# Altium schematic sheet Power.SchDoc
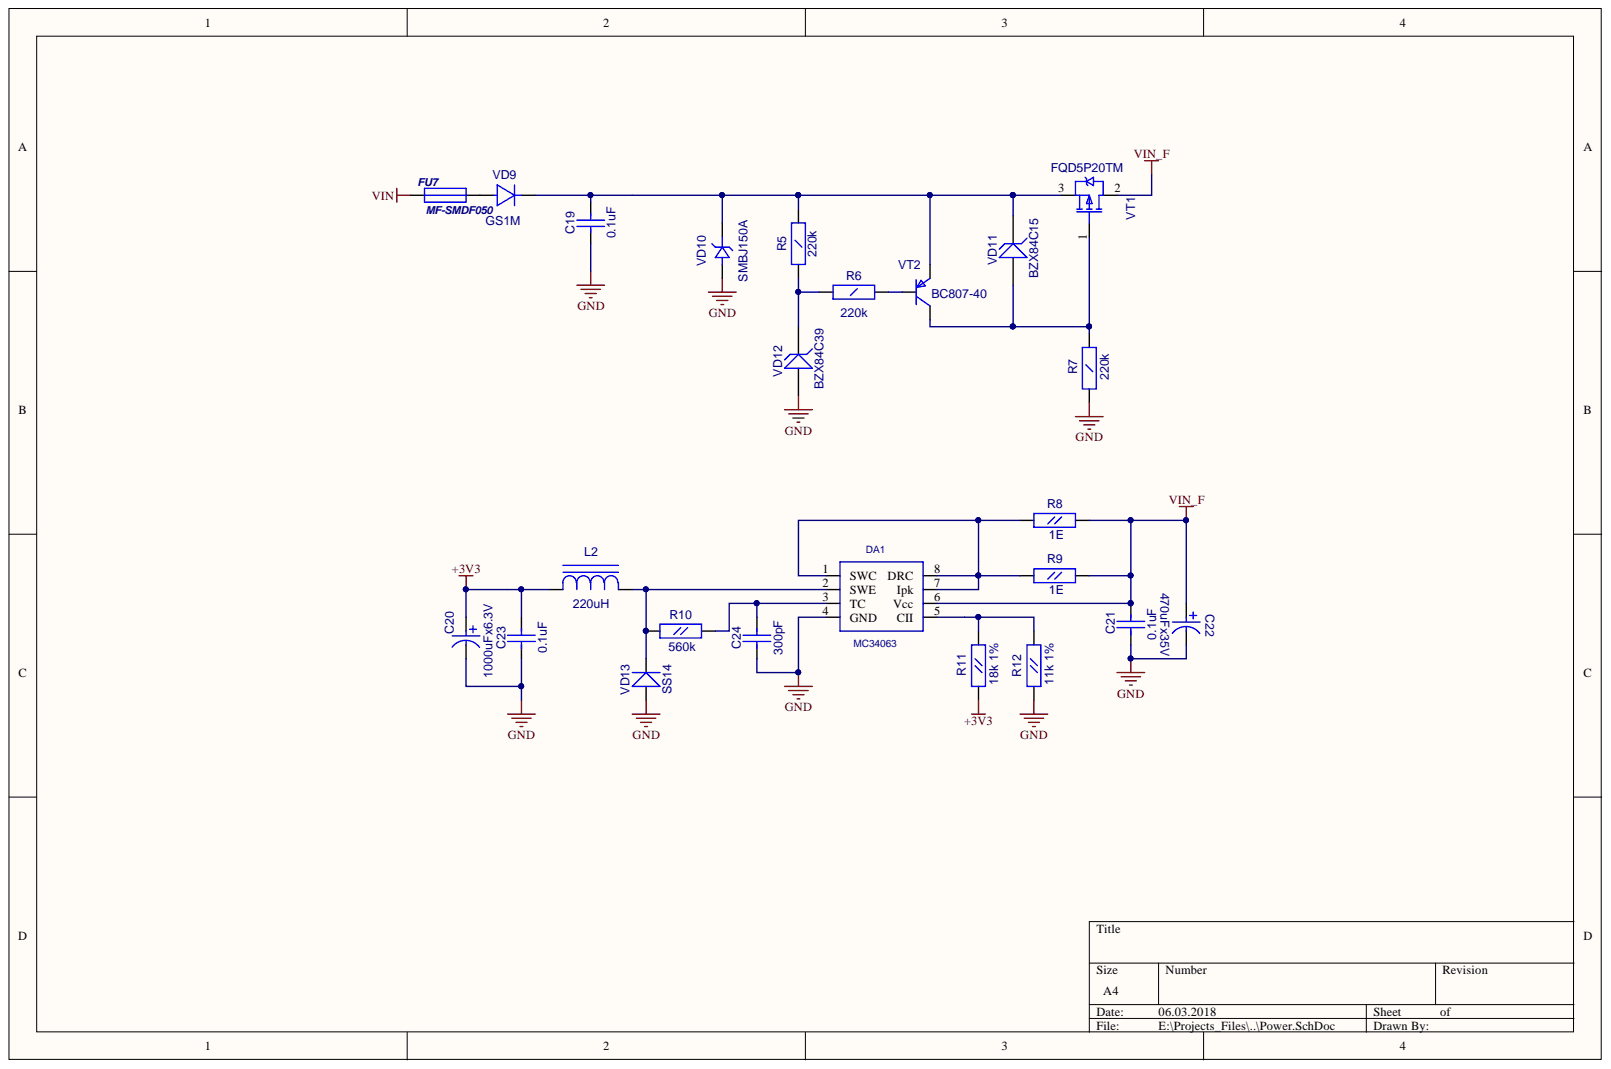
[diagram p0: Power.SchDoc]

## Parts
C19  0.1uF  [CC1206]
C20  1000uFx6.3V  [CC8X3.5]
C21  0.1uF  [CC0603]
C22  470uFx35V  [CC16X10_CAP0.5(1)(Primary)]
C23  0.1uF  [CC0603]
C24  300pF  [CC0603]
DA1  MC34063  [SO-8]
FU7  MF-SMDF050  [MF-SMDF]
L2  220uH  [SDR0805]
R5  220k  [RC1206]
R6  220k  [RC1206]
R7  220k  [RC1206]
R8  1E  [RC0805]
R9  1E  [RC0805]
R10  560k  [RC0603]
R11  18k 1%  [RC0603]
R12  11k 1%  [RC0603]
VD9  GS1M  [SMA]
VD10  SMBJ150A  [SMB]
VD11  BZX84C15  [SOT-23(123)]
VD12  BZX84C39  [SOT-23(123)]
VD13  SS14  [SMA]
VT1  FQD5P20TM  [D-PAK(TO-252AA)]
VT2  BC807-40  [SOT-23(123)]

## Nets
+3V3: C20.1 C23.2 L2.1 R11.1
GND: C19.1 C20.2 C21.1 C22.1 C23.1 C24.1 DA1.4(GND) R7.2 R12.1 VD10.1 VD12.1 VD13.1
VIN: FU7.1
VIN_F: C21.2 C22.2 DA1.6(Vcc) R8.2 R9.2 VT1.2
NetC19_2: C19.2 R5.2 VD9.2 VD10.2 VD11.3 VT1.3 VT2.2
NetC24_2: C24.2 DA1.3(TC) R10.2
NetDA1_1: DA1.1(SWC) DA1.7(Ipk) DA1.8(DRC) R8.1 R9.1
NetDA1_2: DA1.2(SWE) L2.2 R10.1 VD13.2
NetDA1_5: DA1.5(CII) R11.2 R12.2
NetFU7_2: FU7.2 VD9.1
NetR5_1: R5.1 R6.1 VD12.3
NetR6_2: R6.2 VT2.1
NetR7_1: R7.1 VD11.1 VT1.1 VT2.3

## Library data of the parts
C19 = CC1206: Component Kind: Standard; Description: CC1206; Value: 0.1uF; Library Name: gprs_modem_2.SchLib
C20 = CAP0.35: Component Kind: Standard; Description: CAP0.35; Value: 1000uFx6.3V; Library Name: gprs_modem_2.SchLib
C21 C23 C24 = CC0603: Component Kind: Standard; Description: CC0603; Library Name: gprs_modem_2.SchLib
  C21 C23: Value: 0.1uF
  C24: Value: 300pF
C22 = CAP0.5(1): Component Kind: Standard; Description: CAP0.5(1); Value: 470uFx35V; Library Name: gprs_modem_2.SchLib
FU7 = MF-SMDF: Component Kind: Standard; Description: MF-SMDF; Value: MF-SMDF050; Library Name: gprs_modem_2.SchLib
L2 = SDR0805: Component Kind: Standard; Description: SDR0805; Value: 220uH; Library Name: gprs_modem_2.SchLib
R5-R7 = RC1206: Component Kind: Standard; Description: RC1206; Value: 220k; Library Name: gprs_modem_2.SchLib
R8 R9 = RC0805: Component Kind: Standard; Description: RC0805; Value: 1E; Library Name: gprs_modem_2.SchLib
R10-R12 = RC0603: Component Kind: Standard; Description: RC0603; Library Name: gprs_modem_2.SchLib
  R10: Value: 560k
  R11: Value: 18k 1%
  R12: Value: 11k 1%
VD9 = GS1M: Value: {Value}; Component Kind: Standard; Description: GS1M; Library Name: gprs_modem.SchLib
VD10 = SMBJ: Component Kind: Standard; Description: SMBJ; Value: SMBJ150A; Library Name: gprs_modem_2.SchLib
VD11 VD12 = BZX84: Zener Voltage Regulator Diode; Value: {Value}; Component Kind: Standard; Library Name: gprs_modem_2.SchLib
VD13 = SS14: Schottky Rectifier; ComponentField6: Published 8-Jun-2000, Modified 10-July-2000: SIM Model Added.; OriginalName: 10BQ100; Component Kind: Standard; Simulation: 10BQ100; Value: SS14; Library Name: gprs_modem_2.SchLib
VT1 = IRFR9220: HEXFET Power MOSFET; ComponentField7: Published 8-Jun-2000; OriginalName: IRLML5103; Component Kind: Standard; Value: FQD5P20TM; Library Name: gprs_modem_2.SchLib
VT2 = BC857B: ComponentField7: Published 29-Sep-2000; OriginalName: BC846BLT1; Component Kind: Standard; Description: BC857B; Simulation: BC846BLT1; Value: BC807-40; Library Name: gprs_modem.SchLib
DA1 = MC34063: Component Kind: Standard; Description: MC34063; Library Name: Schetchik.SchLib
